# Altium schematic sheet CPU.SchDoc
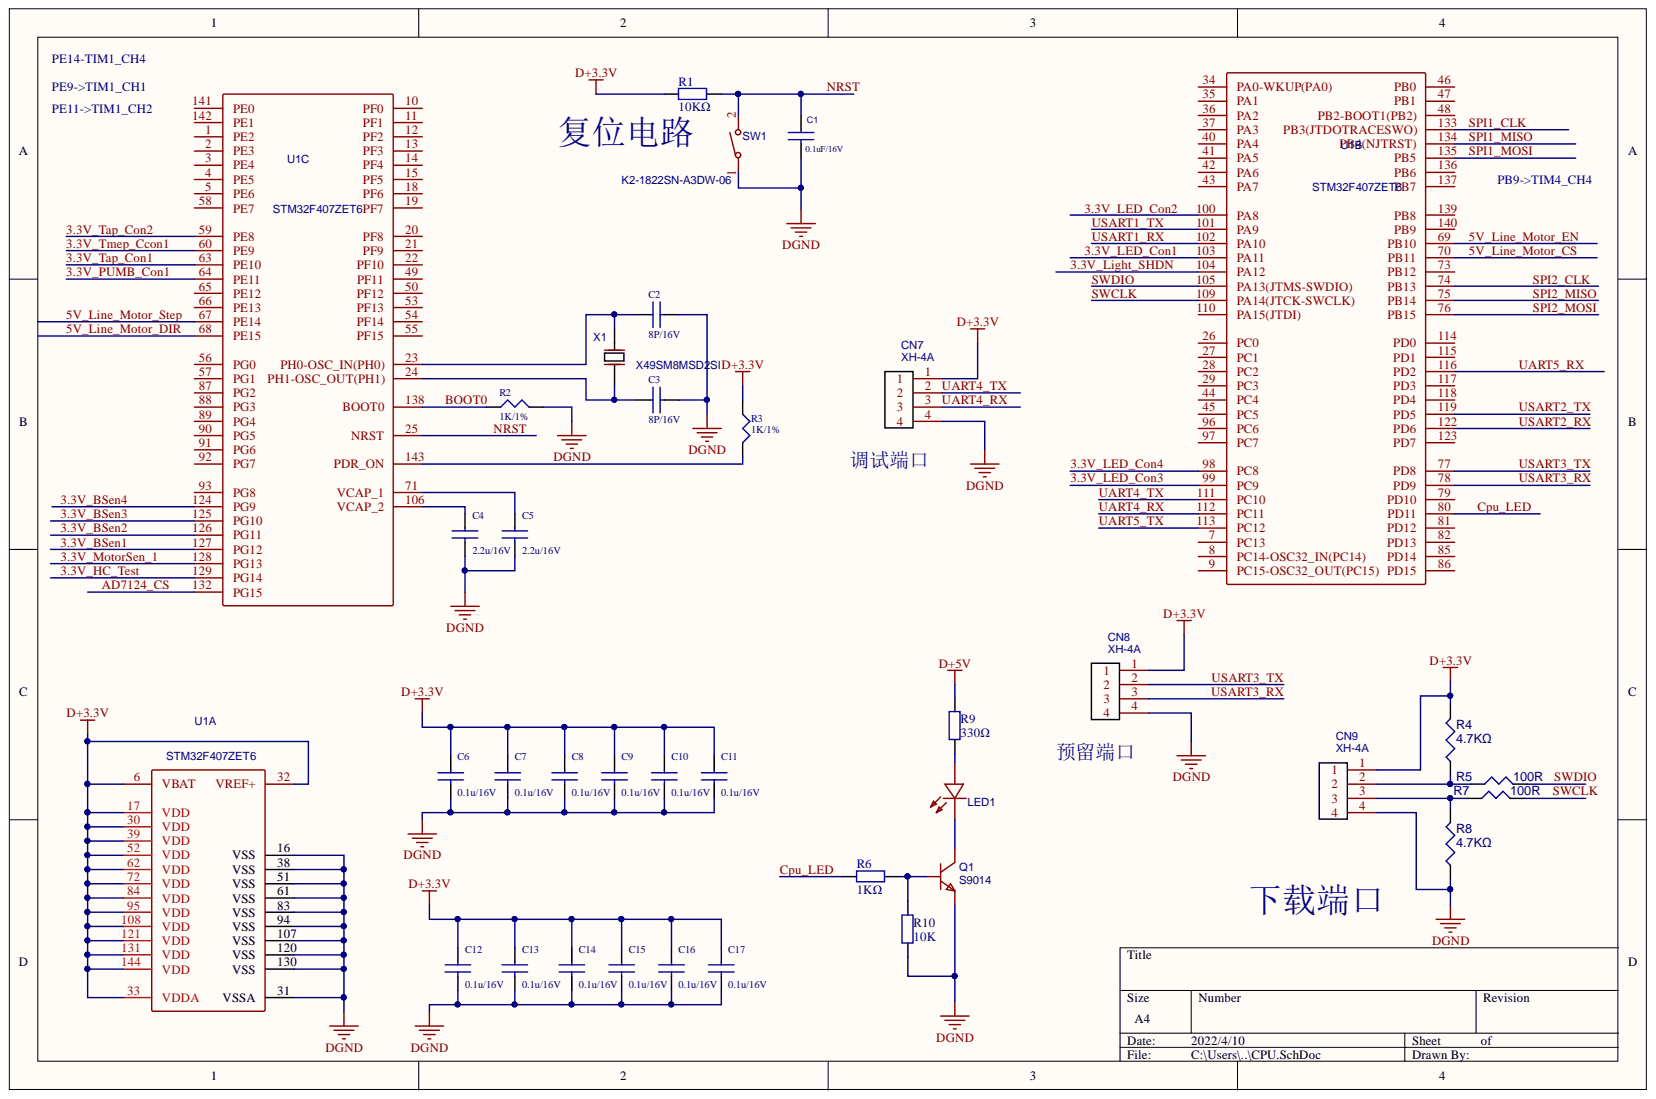
[diagram p0: CPU.SchDoc]

## Parts
C1  0.1uF/16V  [C0603]
C2  8P/16V  [C0603]
C3  8P/16V  [C0603]
C4  2.2u/16V  [C0603]
C5  2.2u/16V  [C0603]
C6  0.1u/16V  [C0603]
C7  0.1u/16V  [C0603]
C8  0.1u/16V  [C0603]
C9  0.1u/16V  [C0603]
C10  0.1u/16V  [C0603]
C11  0.1u/16V  [C0603]
C12  0.1u/16V  [C0603]
C13  0.1u/16V  [C0603]
C14  0.1u/16V  [C0603]
C15  0.1u/16V  [C0603]
C16  0.1u/16V  [C0603]
C17  0.1u/16V  [C0603]
CN7  XH-4A  [XH-4P]
CN8  XH-4A  [XH-4P]
CN9  XH-4A  [XH-4P]
LED1  LTST-C191TGKT  [LED0603]  (value not drawn)
Q1  S9014  [SOT-23]
R1  10KΩ  [R0603]
R2  1K/1%  [R0603]
R3  1K/1%  [R0603]
R4  4.7KΩ  [R0603]
R5  100R  [R0603]
R6  1KΩ  [R0603]
R7  100R  [R0603]
R8  4.7KΩ  [R0603]
R9  330Ω  [R0603]
R10  10K  [R0603]
SW1  K2-1822SN-A3DW-06  [SW1]
U1  STM32F407ZET6  [LQFP-144_L20.0-W20.0-P0.50-LS22.0-BL]  (parts A,B,C)
X1  X49SM8MSD2SI  [晶振]

## Nets
D+3.3V: C6.1 C7.1 C8.1 C9.1 C10.1 C11.1 C12.1 C13.1 C14.1 C15.1 C16.1 C17.1 CN7.1 CN8.1 CN9.1 R1.2 R3.1 R4.2 U1.6(VBAT) U1.17(VDD) U1.30(VDD) U1.32(VREF+) U1.33(VDDA) U1.39(VDD) U1.52(VDD) U1.62(VDD) U1.72(VDD) U1.84(VDD) U1.95(VDD) U1.108(VDD) U1.121(VDD) U1.131(VDD) U1.144(VDD)
D+5V: R9.2
DGND: C1.2 C2.2 C3.2 C4.2 C5.2 C6.2 C7.2 C8.2 C9.2 C10.2 C11.2 C12.2 C13.2 C14.2 C15.2 C16.2 C17.2 CN7.4 CN8.4 CN9.4 Q1.2 R2.2 R8.1 R10.1 SW1.1 U1.16(VSS) U1.31(VSSA) U1.38(VSS) U1.51(VSS) U1.61(VSS) U1.83(VSS) U1.94(VSS) U1.107(VSS) U1.120(VSS) U1.130(VSS)
3.3V_BSen1: U1.127(PG12)
3.3V_BSen2: U1.126(PG11)
3.3V_BSen3: U1.125(PG10)
3.3V_BSen4: U1.124(PG9)
3.3V_HC_Test: U1.129(PG14)
3.3V_LED_Con1: U1.103(PA11)
3.3V_LED_Con2: U1.100(PA8)
3.3V_LED_Con3: U1.99(PC9)
3.3V_LED_Con4: U1.98(PC8)
3.3V_Light_SHDN: U1.104(PA12)
3.3V_MotorSen_1: U1.128(PG13)
3.3V_PUMB_Con1: U1.64(PE11)
3.3V_Tap_Con1: U1.63(PE10)
3.3V_Tap_Con2: U1.59(PE8)
3.3V_Tmep_Ccon1: U1.60(PE9)
5V_Line_Motor_~{CS}: U1.70(PB11)
5V_Line_Motor_DIR: U1.68(PE15)
5V_Line_Motor_EN: U1.69(PB10)
5V_Line_Motor_Step: U1.67(PE14)
AD7124_CS: U1.132(PG15)
BOOT0: R2.1 U1.138(BOOT0)
Cpu_LED: R6.2 U1.80(PD11)
NRST: C1.1 R1.1 SW1.2 U1.25(NRST)
SPI1_CLK: U1.133(PB3(JTDOTRACESWO))
SPI1_MISO: U1.134(PB4(NJTRST))
SPI1_MOSI: U1.135(PB5)
SPI2_CLK: U1.74(PB13)
SPI2_MISO: U1.75(PB14)
SPI2_MOSI: U1.76(PB15)
SWCLK: R7.2 U1.109(PA14(JTCK-SWCLK))
SWDIO: R5.2 U1.105(PA13(JTMS-SWDIO))
UART4_RX: CN7.3 U1.112(PC11)
UART4_TX: CN7.2 U1.111(PC10)
UART5_RX: U1.116(PD2)
UART5_TX: U1.113(PC12)
USART1_RX: U1.102(PA10)
USART1_TX: U1.101(PA9)
USART2_RX: U1.122(PD6)
USART2_TX: U1.119(PD5)
USART3_RX: CN8.3 U1.78(PD9)
USART3_TX: CN8.2 U1.77(PD8)
NetC2_1: C2.1 U1.23(PH0-OSC_IN(PH0)) X1.2
NetC3_1: C3.1 U1.24(PH1-OSC_OUT(PH1)) X1.1
NetC4_1: C4.1 U1.106(VCAP_2)
NetC5_1: C5.1 U1.71(VCAP_1)
NetCN9_2: CN9.2 R4.1 R5.1
NetCN9_3: CN9.3 R7.1 R8.2
NetLED1_1: LED1.1 Q1.3
NetLED1_2: LED1.2 R9.1
NetQ1_1: Q1.1 R6.1 R10.2
NetR3_2: R3.2 U1.143(PDR_ON)

Not connected: U1 1(PE2) 2(PE3) 3(PE4) 4(PE5) 5(PE6) 7(PC13) 8(PC14-OSC32_IN(PC14)) 9(PC15-OSC32_OUT(PC15)) 10(PF0) 11(PF1) 12(PF2) 13(PF3) 14(PF4) 15(PF5) 18(PF6) 19(PF7) 20(PF8) 21(PF9) 22(PF10) 26(PC0) 27(PC1) 28(PC2) 29(PC3) 34(PA0-WKUP(PA0)) 35(PA1) 36(PA2) 37(PA3) 40(PA4) 41(PA5) 42(PA6) 43(PA7) 44(PC4) 45(PC5) 46(PB0) 47(PB1) 48(PB2-BOOT1(PB2)) 49(PF11) 50(PF12) 53(PF13) 54(PF14) 55(PF15) 56(PG0) 57(PG1) 58(PE7) 65(PE12) 66(PE13) 73(PB12) 79(PD10) 81(PD12) 82(PD13) 85(PD14) 86(PD15) 87(PG2) 88(PG3) 89(PG4) 90(PG5) 91(PG6) 92(PG7) 93(PG8) 96(PC6) 97(PC7) 110(PA15(JTDI)) 114(PD0) 115(PD1) 117(PD3) 118(PD4) 123(PD7) 136(PB6) 137(PB7) 139(PB8) 140(PB9) 141(PE0) 142(PE1)

## Library data of the parts
C1 C6-C17 = CAP NP 0805: Component Kind: Standard
  C6-C17: Description: 0.1u/16V; Library Name: C:\Users\Lenovo\Desktop\定量PCR\电路图\PD板\PD.PcbLib
  C1: Library Name: RAPIDS_ZJB.IntLib
C2-C5 = CAP NP 0805: 0.1u/16V; Component Kind: Standard; Library Name: C:\Users\Lenovo\Desktop\定量PCR\电路图\PD板\PD.PcbLib
CN7-CN9 = XH-4A: BOM_Manufacturer: BOOMELE; BOM_JLCPCB Part Class: Extended Part; BOM_Manufacturer Part: XH-4A; BOM_Supplier: LCSC; BOM_Supplier Part: C37815; Component Kind: Standard; spicePre: C; spiceSymbolName: XH-4A
LED1 = LTST-C191TGKT: BOM_Manufacturer: LITEON; Contributor: LCSC; BOM_Manufacturer Part: LTST-C191TGKT; BOM_Supplier: LCSC; BOM_Supplier Part: C125101; Component Kind: Standard; spicePre: L; spiceSymbolName: LTST-C191TGKT
Q1 = S9014: BOM_Manufacturer: BORN伯恩半导体; Contributor: LCSC; BOM_JLCPCB Part Class: Extended Part; BOM_Manufacturer Part: S9014; BOM_Supplier: LCSC; BOM_Supplier Part: C920324; Component Kind: Standard; spicePre: Q; spiceSymbolName: S9014
R1 R6 R9 R10 = 0603 1.5KΩ (1501) 1%: 贴片电阻; Component Kind: Standard; ComponentLink1Description: 供应商链接; ComponentLink1URL: http://www.szlcsc.com/product/details_23570.html; Library Name: RES.SchLib; Package: 0603; Supplier: LC; SuppliersPartNumber: C22843
R2 R3 R5 R7 = RES 0805: Component Kind: Standard
  R2 R3: Library Name: C-LifeReal_1.SchLib
  R5 R7: Library Name: C-LifeReal.SchLib
R4 R8 = RESISTOR_Dup1: Component Kind: Standard
SW1 = K2-1822SN-A3DW-06: BOM_Manufacturer: Korean Hroparts Elec; Contributor: LCSC; BOM_JLCPCB Part Class: Extended Part; BOM_Manufacturer Part: K2-1822SN-A3DW-06; BOM_Supplier: LCSC; BOM_Supplier Part: C502300; Component Kind: Standard; spicePre: S; spiceSymbolName: K2-1822SN-A3DW-06
U1 = STM32F407ZET6: BOM_Manufacturer: ST; subpart_no: 3; tags: STM32; BOM_JLCPCB Part Class: Extended Part; BOM_Manufacturer Part: STM32F407ZET6; BOM_Supplier: LCSC; BOM_Supplier Part: C36869; Component Kind: Standard; image: http://image.lceda.cn/szlcsc/C36869.jpg; Library Name: CPU.SCHLIB; spicePre: U; spiceSymbolName: STM32F407ZET6
X1 = 49M-008000-FD4D14: BOM_Manufacturer: AKER(安碁科技); Contributor: LCSC; BOM_Manufacturer Part: 49M-008000-FD4D14; BOM_Supplier: LCSC; BOM_Supplier Part: C470255; Component Kind: Standard; spicePre: X; spiceSymbolName: 49M-008000-FD4D14
